# Altium schematic sheet LEDs.SchDoc
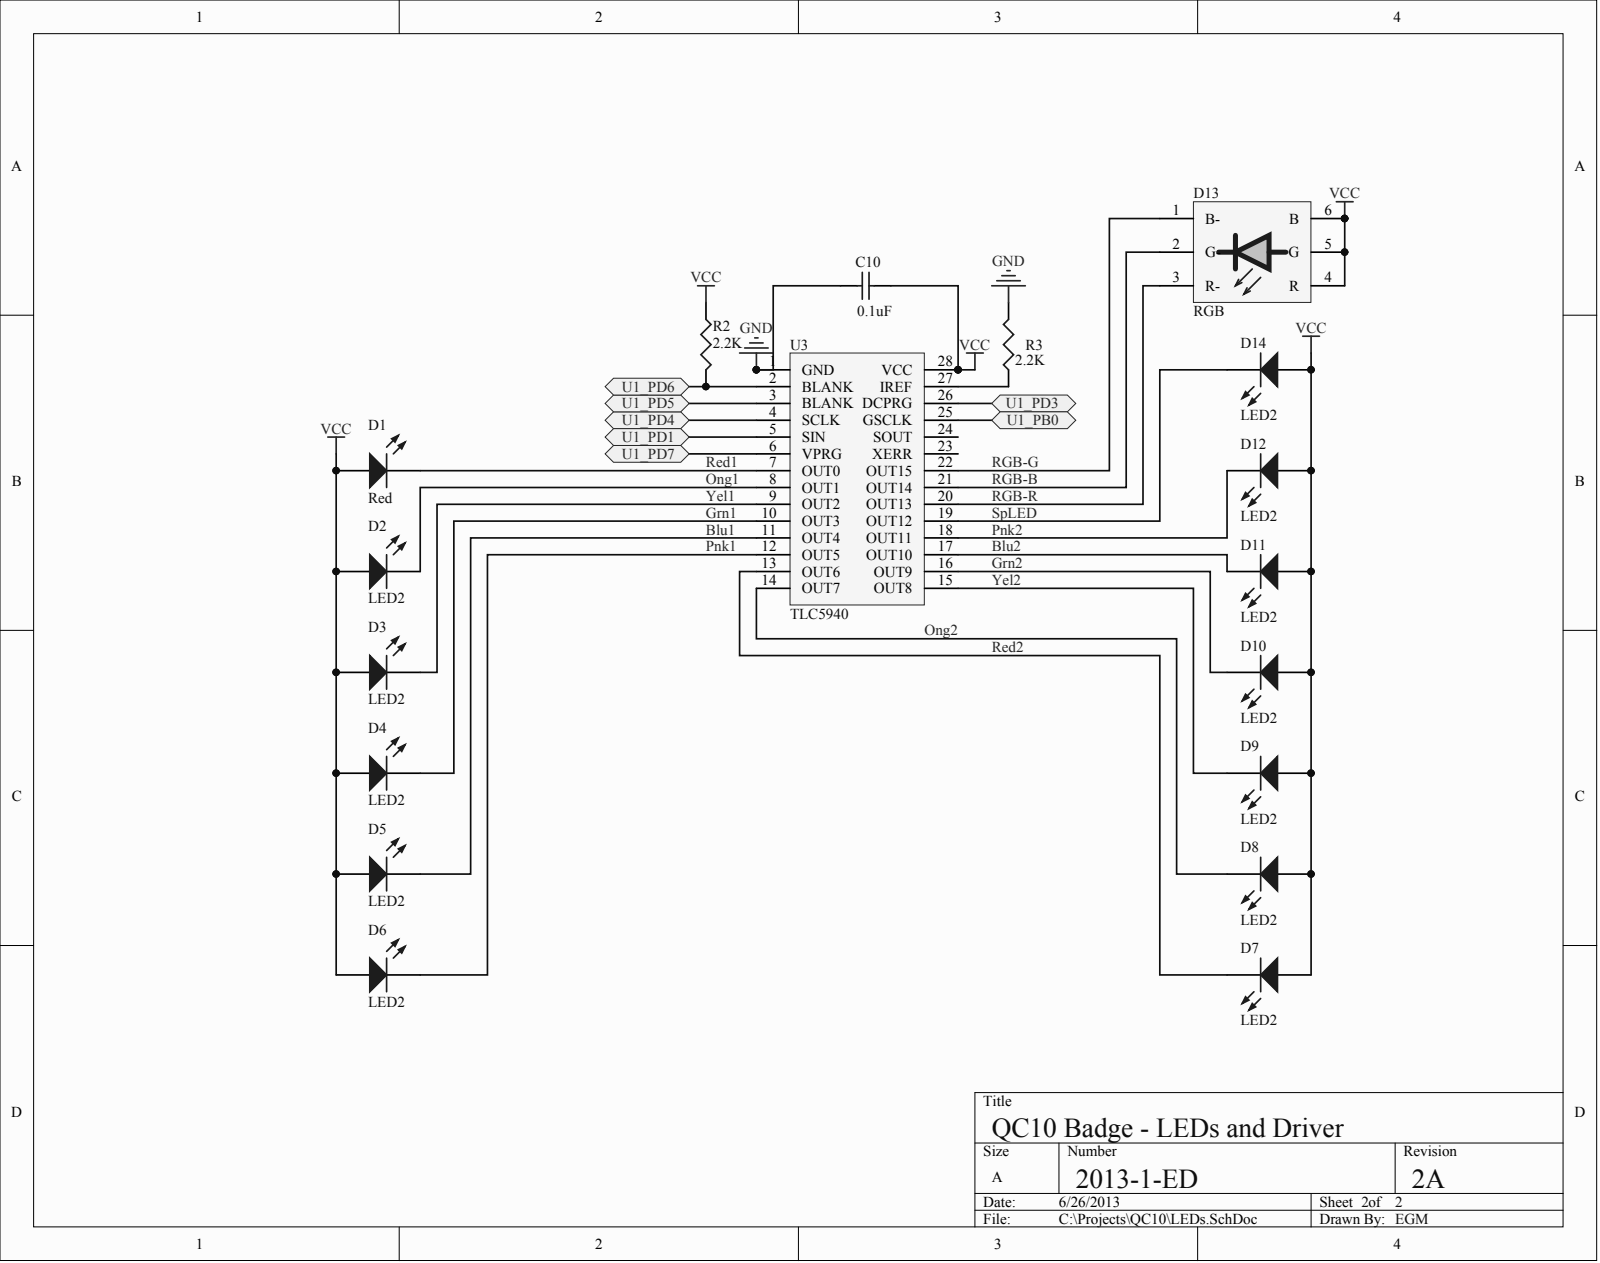
[diagram p0: LEDs.SchDoc]

## Parts
C10  value 0.1uF  (hidden comment: Cap)
D1  Red
D2  LED2
D3  LED2
D4  LED2
D5  LED2
D6  LED2
D7  LED2
D8  LED2
D9  LED2
D10  LED2
D11  LED2
D12  LED2
D13  RGB
D14  LED2
R2  value 2.2K  (hidden comment: Res3)
R3  value 2.2K  (hidden comment: Res3)
U3  TLC5940

## Ports
U1_PB0, U1_PD1, U1_PD3, U1_PD4, U1_PD5, U1_PD6, U1_PD7

## Nets
GND: C10.1 R3.2 U3.1(GND)
VCC: C10.2 D1.1 D2.1 D3.1 D4.1 D5.1 D6.1 D7.1 D8.1 D9.1 D10.1 D11.1 D12.1 D13.4(R) D13.5(G) D13.6(B) D14.1 R2.1 U3.28(VCC)
BLU1: D5.2 U3.11(OUT4)
BLU2: D11.2 U3.17(OUT10)
GRN1: D4.2 U3.10(OUT3)
GRN2: D10.2 U3.16(OUT9)
ONG1: D2.2 U3.8(OUT1)
ONG2: D8.2 U3.14(OUT7)
PNK1: D6.2 U3.12(OUT5)
PNK2: D12.2 U3.18(OUT11)
RED1: D1.2 U3.7(OUT0)
RED2: D7.2 U3.13(OUT6)
RGB-B: D13.2(G-) U3.21(OUT14)
RGB-G: D13.1(B-) U3.22(OUT15)
RGB-R: D13.3(R-) U3.20(OUT13)
SPLED: D14.2 U3.19(OUT12)
U1_PB0: U3.25(GSCLK) ; port U1_PB0
U1_PD1: U3.5(SIN) ; port U1_PD1
U1_PD3: U3.26(DCPRG) ; port U1_PD3
U1_PD4: U3.4(SCLK) ; port U1_PD4
U1_PD5: U3.3(BLANK) ; port U1_PD5
U1_PD6: R2.2 U3.2(BLANK) ; port U1_PD6
U1_PD7: U3.6(VPRG) ; port U1_PD7
YEL1: D3.2 U3.9(OUT2)
YEL2: D9.2 U3.15(OUT8)
NetR3_1: R3.1 U3.27(IREF)

Not connected: U3 23(XERR) 24(SOUT)

## Library data of the parts
C10 = (no symbol name): Manufacturer: Kemmet; PN: C1206C104K4RACTU; PackageReference: 3216 (1210); PackageDescription: Chip Capacitor
D1 = (no symbol name): Manufacturer: Lite-On; PN: LTST-C193KRKT-5A; PackageReference: 0603 (mm); PackageDescription: SM LED; 2 Flat Leads; Value: Red
D2 D8 = (no symbol name): Manufacturer: Lite-On; PN: LTST-C193KFKT-5A; Value: Orange; PackageReference: 0603 (mm); PackageDescription: SM LED; 2 Flat Leads
D3 D9 = (no symbol name): Manufacturer: Lite-On; PN: LTST-C193KSKT-5A; Value: Yellow; PackageReference: 0603 (mm); PackageDescription: SM LED; 2 Flat Leads
D4 D10 = (no symbol name): Manufacturer: Lite-On; PN: LTST-C193KGKT-5A; Value: Green; PackageReference: 0603 (mm); PackageDescription: SM LED; 2 Flat Leads
D5 D11 = (no symbol name): Manufacturer: Lite-On; PN: LTST-C194TBKT; Value: Blue; PackageReference: 0603 (mm); PackageDescription: SM LED; 2 Flat Leads
D6 D12 = (no symbol name): Manufacturer: Panasonic; PN: LNJP12X8ARA; Value: Pink; PackageReference: 0603 (mm); PackageDescription: SM LED; 2 Flat Leads
D7 D14 = (no symbol name): Manufacturer: Lite-On; PN: LTST-C193KRKT-5A; Value: Red
  D7: PackageReference: 0603 (mm); PackageDescription: SM LED; 2 Flat Leads
  D14: PackageReference: LTST-C193KRKT-5A; PackageDescription: SM LED; 2 Flat Leads; RED
D13 = (no symbol name): Manufacturer: Avago; PN: ASMT-YTB2-0BB02; PackageReference: PLCC-6; Value: RGB
R2 R3 = (no symbol name): Manufacturer: Panasonic; PN: ERJ-T08J222V; PackageReference: 0603 (mm); PackageDescription: Chip Resistor
U3 = (no symbol name): Manufacturer: TI; PN: TLC5940PWP; PackageReference: HTSSPO-28
